# Altium schematic sheet Car.SchDoc
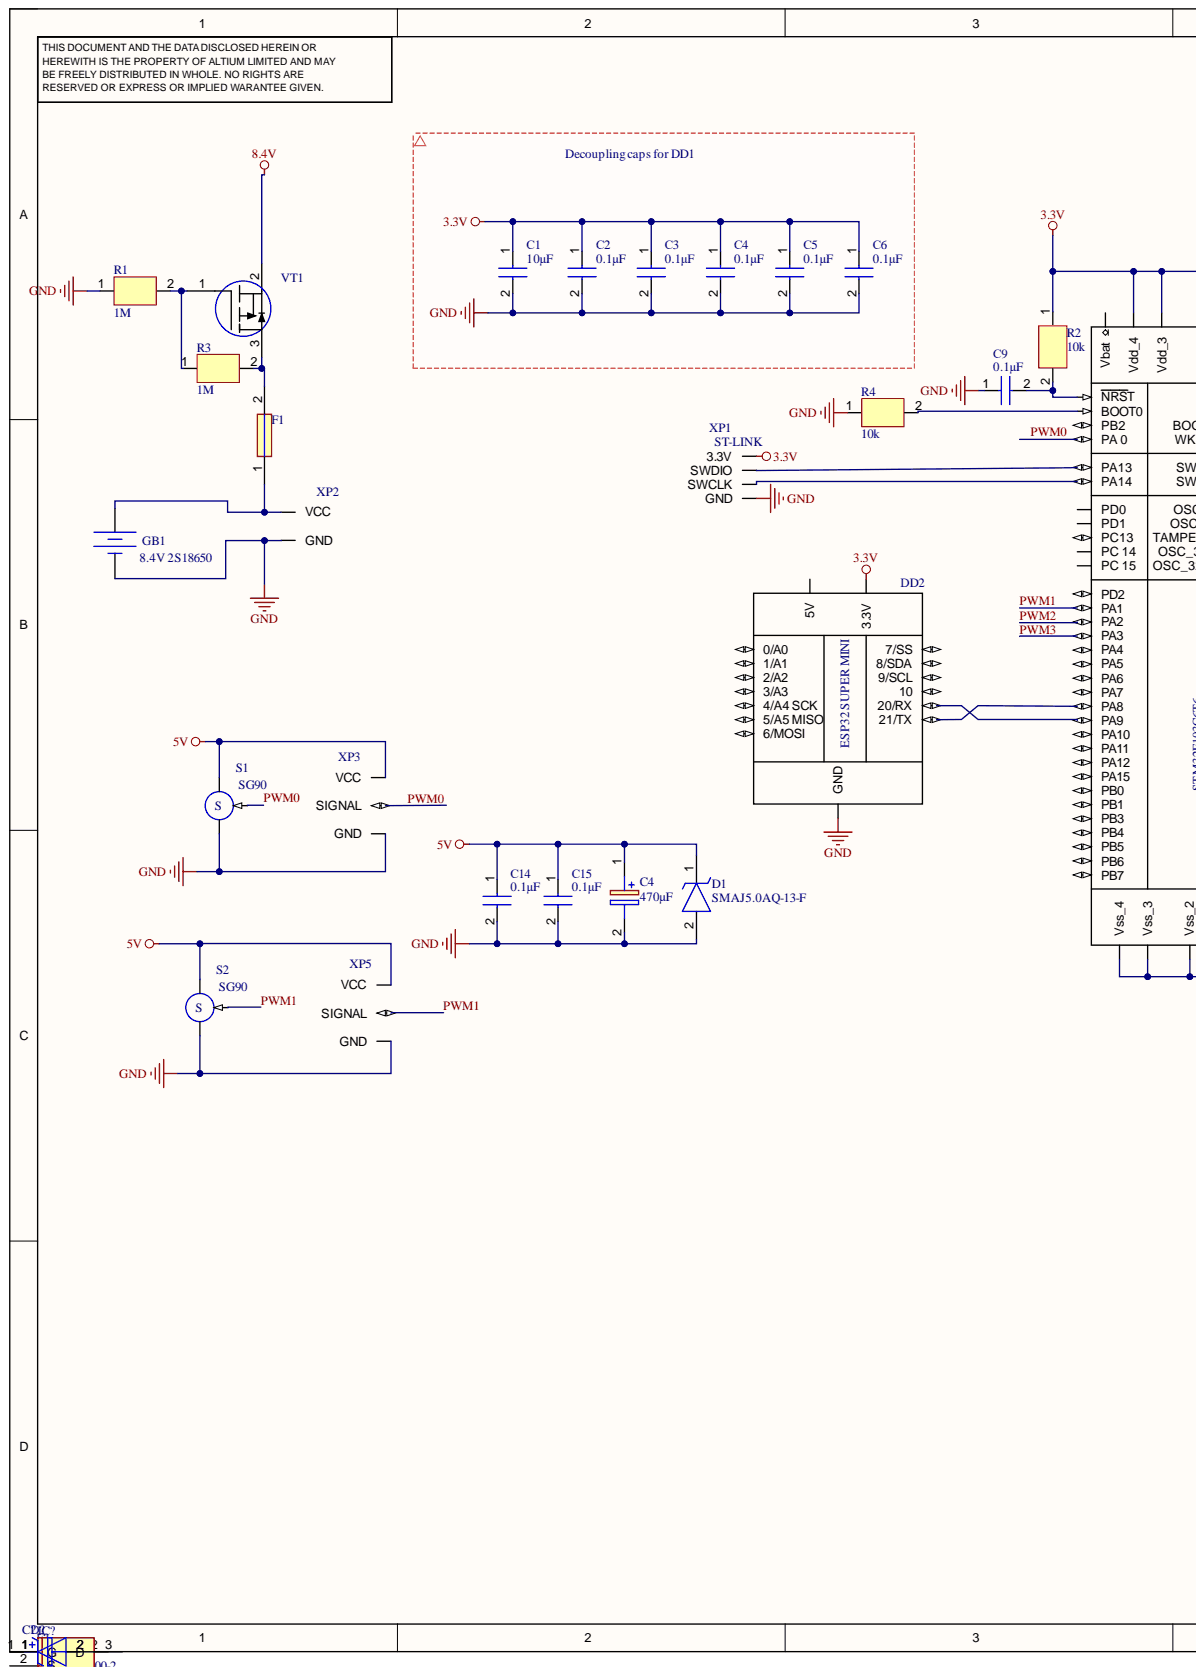
[diagram p0: Car.SchDoc, left]
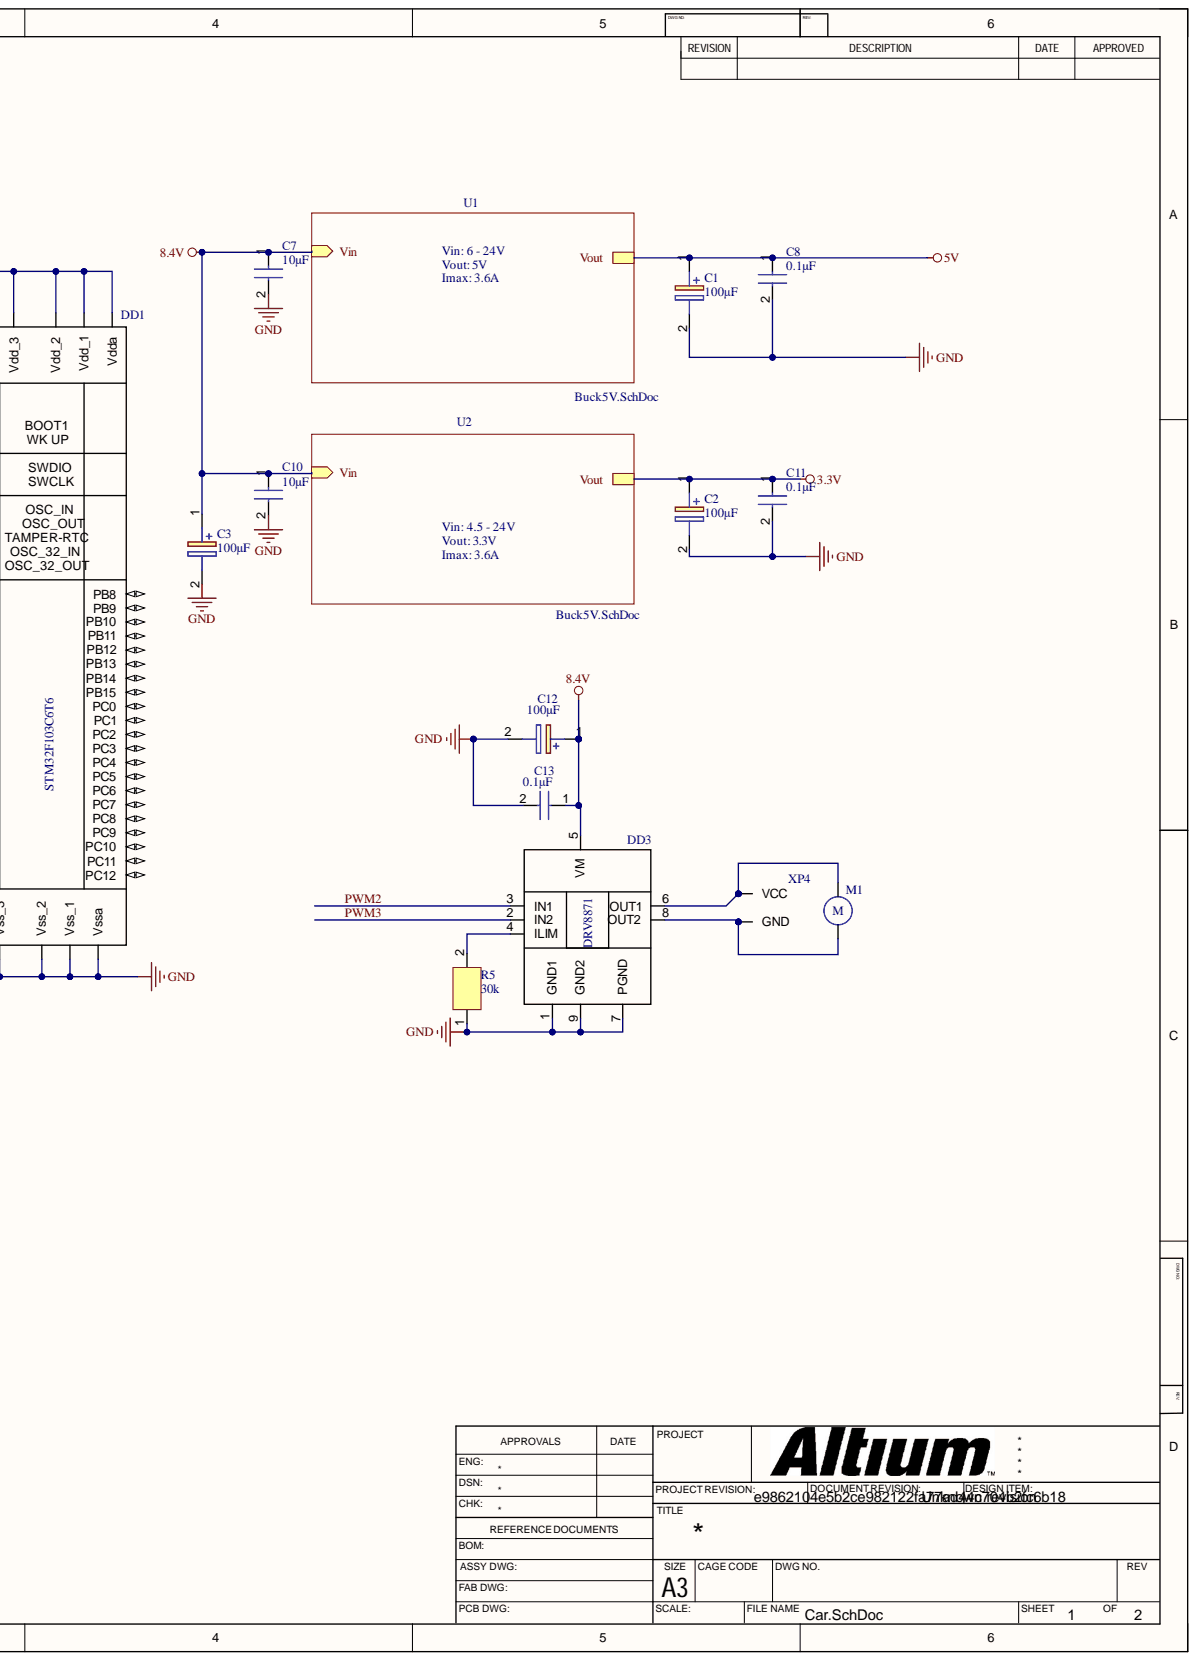
[diagram p1: Car.SchDoc, right]

## Parts
C1  100µF  [T491C]
C2  100µF  [T491C]
C3  100µF  [T491C]
C4  470µF  [EEEFK1V331P]
D1  SMAJ5.0AQ-13-F  [SODFL5226X240N]
DD1  (no value)  [STM32F103C6T6]
DD2  (no value)  [ESP32C3_SUPER_MINI]
DD3  (no value)  [DRV8871DDA]
F1  Schurter  USI 8053370874 I = 4A, U = 32V  [FUSC3116X70N]  (value not drawn)
GB1  DC Magnitude: 8.4V 2S18650  (hidden comment: Constant Voltage Source)
M1  (no value)
R1  1M  [CAPC2012X145N]
R2  10k  [CAPC2012X145N]
R3  1M  [CAPC2012X145N]
R4  10k  [CAPC2012X145N]
R5  30k  [CAPC2012X145N]
S1  SG90
S2  SG90
VT1  IRLML6401  [SOT95P237X112-3N]  (value not drawn)
XP1  ST-LINK  [ST-LINK]
XP2  (no value)  [EXT_SENSOR]
XP3  (no value)  [EXT_SENSOR_3pin]
XP4  (no value)  [EXT_SENSOR]
XP5  (no value)  [EXT_SENSOR_3pin]
С1  10µF  [CAPC2012X145N]
С2  0.1µF  [CAPC2012X145N]
С3  0.1µF  [CAPC2012X145N]
С4  0.1µF  [CAPC2012X145N]
С5  0.1µF  [CAPC2012X145N]
С6  0.1µF  [CAPC2012X145N]
С7  10µF  [CAPC2012X145N]
С8  0.1µF  [CAPC2012X145N]
С9  0.1µF  [CAPC2012X145N]
С10  10µF  [CAPC2012X145N]
С11  0.1µF  [CAPC2012X145N]
С12  100µF  [EEE0JA331XP]
С13  0.1µF  [CAPC2012X145N]
С14  0.1µF  [CAPC2012X145N]
С15  0.1µF  [CAPC2012X145N]

## Nets
3.3V: C2.1 DD1.13(Vdda) DD1.19(Vdd_4) DD1.32(Vdd_1) DD1.48(Vdd_2) DD1.64(Vdd_3) DD2.3.3V R2.1 XP1.3.3V С1.1 С2.1 С3.1 С4.1 С5.1 С6.1 С11.1
5V: C1.1 C4.1 D1.1 S1.1 S2.1 XP3.1 XP5.1 С8.1 С14.1 С15.1
8.4V: C3.1 DD3.5(VM) VT1.2 С7.1 С10.1 С12.1 С13.1
GND: C1.2 C2.2 C3.2 C4.2 D1.2 DD1.12(Vssa) DD1.18(Vss_4) DD1.31(Vss_1) DD1.47(Vss_2) DD1.63(Vss_3) DD2.GND DD3.1(GND1) DD3.7(PGND) DD3.9(GND2) GB1.2 R1.1 R4.1 R5.1 S1.2 S2.2 XP1.GND XP2.2 XP3.3 XP5.3 С1.2 С2.2 С3.2 С4.2 С5.2 С6.2 С7.2 С8.2 С9.1 С10.2 С11.2 С12.2 С13.2 С14.2 С15.2
PWM0: DD1.14(PA_0) S1.3 XP3.2
PWM1: DD1.15(PA1) S2.3 XP5.2
PWM2: DD1.16(PA2) DD3.3(IN1)
PWM3: DD1.17(PA3) DD3.2(IN2)
NetDD1_1: DD1.1(Vbat)
NetDD1_2: DD1.2(PC13)
NetDD1_3: DD1.3(PC_14)
NetDD1_4: DD1.4(PC_15)
NetDD1_5: DD1.5(PD0)
NetDD1_6: DD1.6(PD1)
NetDD1_7: DD1.7(NRST) R2.2 С9.2
NetDD1_8: DD1.8(PC0)
NetDD1_9: DD1.9(PC1)
NetDD1_10: DD1.10(PC2)
NetDD1_11: DD1.11(PC3)
NetDD1_20: DD1.20(PA4)
NetDD1_21: DD1.21(PA5)
NetDD1_22: DD1.22(PA6)
NetDD1_23: DD1.23(PA7)
NetDD1_24: DD1.24(PC4)
NetDD1_25: DD1.25(PC5)
NetDD1_26: DD1.26(PB0)
NetDD1_27: DD1.27(PB1)
NetDD1_28: DD1.28(PB2)
NetDD1_29: DD1.29(PB10)
NetDD1_30: DD1.30(PB11)
NetDD1_33: DD1.33(PB12)
NetDD1_34: DD1.34(PB13)
NetDD1_35: DD1.35(PB14)
NetDD1_36: DD1.36(PB15)
NetDD1_37: DD1.37(PC6)
NetDD1_38: DD1.38(PC7)
NetDD1_39: DD1.39(PC8)
NetDD1_40: DD1.40(PC9)
NetDD1_41: DD1.41(PA8) DD2.GPIO21(21/TX)
NetDD1_42: DD1.42(PA9) DD2.GPIO20(20/RX)
NetDD1_43: DD1.43(PA10)
NetDD1_44: DD1.44(PA11)
NetDD1_45: DD1.45(PA12)
NetDD1_46: DD1.46(PA13) XP1.SWDIO
NetDD1_49: DD1.49(PA14) XP1.SWCLK
NetDD1_50: DD1.50(PA15)
NetDD1_51: DD1.51(PC10)
NetDD1_52: DD1.52(PC11)
NetDD1_53: DD1.53(PC12)
NetDD1_54: DD1.54(PD2)
NetDD1_55: DD1.55(PB3)
NetDD1_56: DD1.56(PB4)
NetDD1_57: DD1.57(PB5)
NetDD1_58: DD1.58(PB6)
NetDD1_59: DD1.59(PB7)
NetDD1_60: DD1.60(BOOT0) R4.2
NetDD1_61: DD1.61(PB8)
NetDD1_62: DD1.62(PB9)
NetDD2_5V: DD2.5V
NetDD2_GPIO0: DD2.GPIO0(0/A0)
NetDD2_GPIO1: DD2.GPIO1(1/A1)
NetDD2_GPIO2: DD2.GPIO2(2/A2)
NetDD2_GPIO3: DD2.GPIO3(3/A3)
NetDD2_GPIO4: DD2.GPIO4(4/A4_SCK)
NetDD2_GPIO5: DD2.GPIO5(5/A5_MISO)
NetDD2_GPIO6: DD2.GPIO6(6/MOSI)
NetDD2_GPIO7: DD2.GPIO7(7/SS)
NetDD2_GPIO8: DD2.GPIO8(8/SDA)
NetDD2_GPIO9: DD2.GPIO9(9/SCL)
NetDD2_GPIO10: DD2.GPIO10
NetDD3_4: DD3.4(ILIM) R5.2
NetDD3_6: DD3.6(OUT1) M1.1 XP4.1
NetDD3_8: DD3.8(OUT2) M1.2 XP4.2
NetF1_1: F1.1 GB1.1 XP2.1
NetF1_2: F1.2 R3.2 VT1.3
NetR1_2: R1.2 R3.1 VT1.1

## Library data of the parts
C1-C3 = Capacitor Tantal Case C: Capacitor Polarised; Manufacturer_Name: YAGEO; Manufacturer_Part_Number: T491C107M016AT; Component Kind: Standard; Datasheet Link: https://content.kemet.com/datasheets/KEM_T2005_T491.pdf; Height: 2.8mm; Library Name: SamacSys.SchLib; Mouser Part Number: 80-T491C107M016AT; Mouser Price/Stock: https://www.mouser.co.uk/ProductDetail/KEMET/T491C107M016AT?qs=W04EqCCJmmuOmj0nZmoJtg%3D%3D
C4 = EEE-FK1E471P: Capacitor Polarised; Manufacturer_Name: Panasonic; Arrow Part Number: EEE-FK1E471P; Arrow Price/Stock: https://www.arrow.com/en/products/eee-fk1e471p/panasonic?utm_currency=USD&region=europe; Component Kind: Standard; Datasheet Link: http://industrial.panasonic.com/cdbs/www-data/pdf/RDE0000/ABA0000C1181.pdf; Library Name: SamacSys.SchLib; Manufacturer_Part_Number: EEE-FK1E471P; Mouser Part Number: 667-EEE-FK1E471P; Mouser Price/Stock: https://www.mouser.co.uk/ProductDetail/Panasonic/EEE-FK1E471P?qs=qE6bgDGEOCvvsolWS4cebg%3D%3D
D1 = SMAJ5.0AQ-13-F: TVS Diode (Uni-directional); Manufacturer_Name: Diodes Incorporated; Component Kind: Standard; Datasheet Link: https://www.mouser.de/datasheet/2/115/ds40739-1500914.pdf; Height: 2.4mm; Library Name: SamacSys.SchLib; Manufacturer_Part_Number: SMAJ5.0AQ-13-F; Mouser Part Number: 621-SMAJ5.0AQ-13-F; Mouser Price/Stock: https://www.mouser.co.uk/ProductDetail/Diodes-Incorporated/SMAJ5.0AQ-13-F?qs=17u8i%2FzlE8%2FlC4rBSQmAww%3D%3D
DD1 = STM32F103C6T6: Component Kind: Standard; Library Name: STM32F103C6T6.SchLib
DD2 = ESP32_SUPER_MINI: Component Kind: Standard; Library Name: SamacSys.SchLib
DD3 = DRV8871DDA: Component Kind: Standard; Library Name: STM32F103C6T6.SchLib
F1 = SF-1206S500-2: Manufacturer_Name: Bourns; Arrow Part Number: SF-1206S500-2; Arrow Price/Stock: https://www.arrow.com/en/products/sf-1206s500-2/bourns?region=nac; Component Kind: Standard; Datasheet Link: https://componentsearchengine.com/Datasheets/2/SF-1206S500-2.pdf; Height: 0.7mm; Library Name: SamacSys.SchLib; Manufacturer_Part_Number: SF-1206S500-2; Mouser Part Number: 652-SF-1206S500-2; Mouser Price/Stock: https://www.mouser.co.uk/ProductDetail/Bourns/SF-1206S500-2?qs=GIQXYiEeHGD%252By2V9Xr7ztw%3D%3D
GB1 = Battery: SimulationGenericComponent: True; Component Kind: Standard; Description: Constant Voltage Source; Library Name: Simulation Generic Components.IntLib; Simulation: Vconst
M1 = Motor: Component Kind: Standard; Library Name: STM32F103C6T6.SchLib
R1-R5 = 0805R: Resistor; Manufacturer_Name: SAMSUNG; Manufacturer_Part_Number: CL21A106KAYNNNE; Arrow Part Number: CL21A106KAYNNNE; Arrow Price/Stock: https://www.arrow.com/en/products/cl21a106kaynnne/samsung-electro-mechanics?utm_currency=USD&region=nac; Component Kind: Standard; Datasheet Link: https://datasheet.datasheetarchive.com/originals/distributors/Datasheets_SAMA/6a45835c9b7400e9b49c55c38a8ff4a2.pdf; Height: 1.45mm; Library Name: SamacSys.SchLib; Mouser Part Number: 187-CL21A106KAYNNNE; Mouser Price/Stock: https://www.mouser.co.uk/ProductDetail/Samsung-Electro-Mechanics/CL21A106KAYNNNE?qs=yOVawPpwOwmTXAd4dJtNjA%3D%3D
S1 S2 = Servo: Component Kind: Standard; Library Name: STM32F103C6T6.SchLib
VT1 = IRLML6401: Integrated Circuit; Manufacturer_Name: UMW(Youtai Semiconductor Co.; Component Kind: Standard; Height: 1.12mm; Library Name: SamacSys.SchLib; Manufacturer_Part_Number: IRLML6401
XP2 XP4 = EXT_SENSOR: Component Kind: Standard; Library Name: STM32F103C6T6.SchLib
XP3 XP5 = EXT_SENSOR_3pin: Component Kind: Standard; Library Name: STM32F103C6T6.SchLib
С1-С11 С13-С15 = 0805C: Capacitor; Manufacturer_Name: SAMSUNG; Manufacturer_Part_Number: CL21A106KAYNNNE; Arrow Part Number: CL21A106KAYNNNE; Arrow Price/Stock: https://www.arrow.com/en/products/cl21a106kaynnne/samsung-electro-mechanics?utm_currency=USD&region=nac; Component Kind: Standard; Datasheet Link: https://datasheet.datasheetarchive.com/originals/distributors/Datasheets_SAMA/6a45835c9b7400e9b49c55c38a8ff4a2.pdf; Height: 1.45mm; Library Name: SamacSys.SchLib; Mouser Part Number: 187-CL21A106KAYNNNE; Mouser Price/Stock: https://www.mouser.co.uk/ProductDetail/Samsung-Electro-Mechanics/CL21A106KAYNNNE?qs=yOVawPpwOwmTXAd4dJtNjA%3D%3D
С12 = EEE-FK1E101XP: Capacitor Polarised; Manufacturer_Name: Panasonic; Arrow Part Number: EEE-FK1E101XP; Arrow Price/Stock: https://www.arrow.com/en/products/eee-fk1e101xp/panasonic?region=nac; Component Kind: Standard; Datasheet Link: http://industrial.panasonic.com/cdbs/www-data/pdf/RDE0000/ABA0000C1181.pdf; Height: 7.8mm; Library Name: SamacSys.SchLib; Manufacturer_Part_Number: EEE-FK1E101XP; Mouser Part Number: 667-EEE-FK1E101XP; Mouser Price/Stock: https://www.mouser.co.uk/ProductDetail/Panasonic/EEE-FK1E101XP?qs=qE6bgDGEOCsW6NVslB4juQ%3D%3D
XP1 = ST-LINK: Component Kind: Standard; Library Name: STM32F103C6T6.SchLib
